# Altium schematic sheet connectors.SchDoc
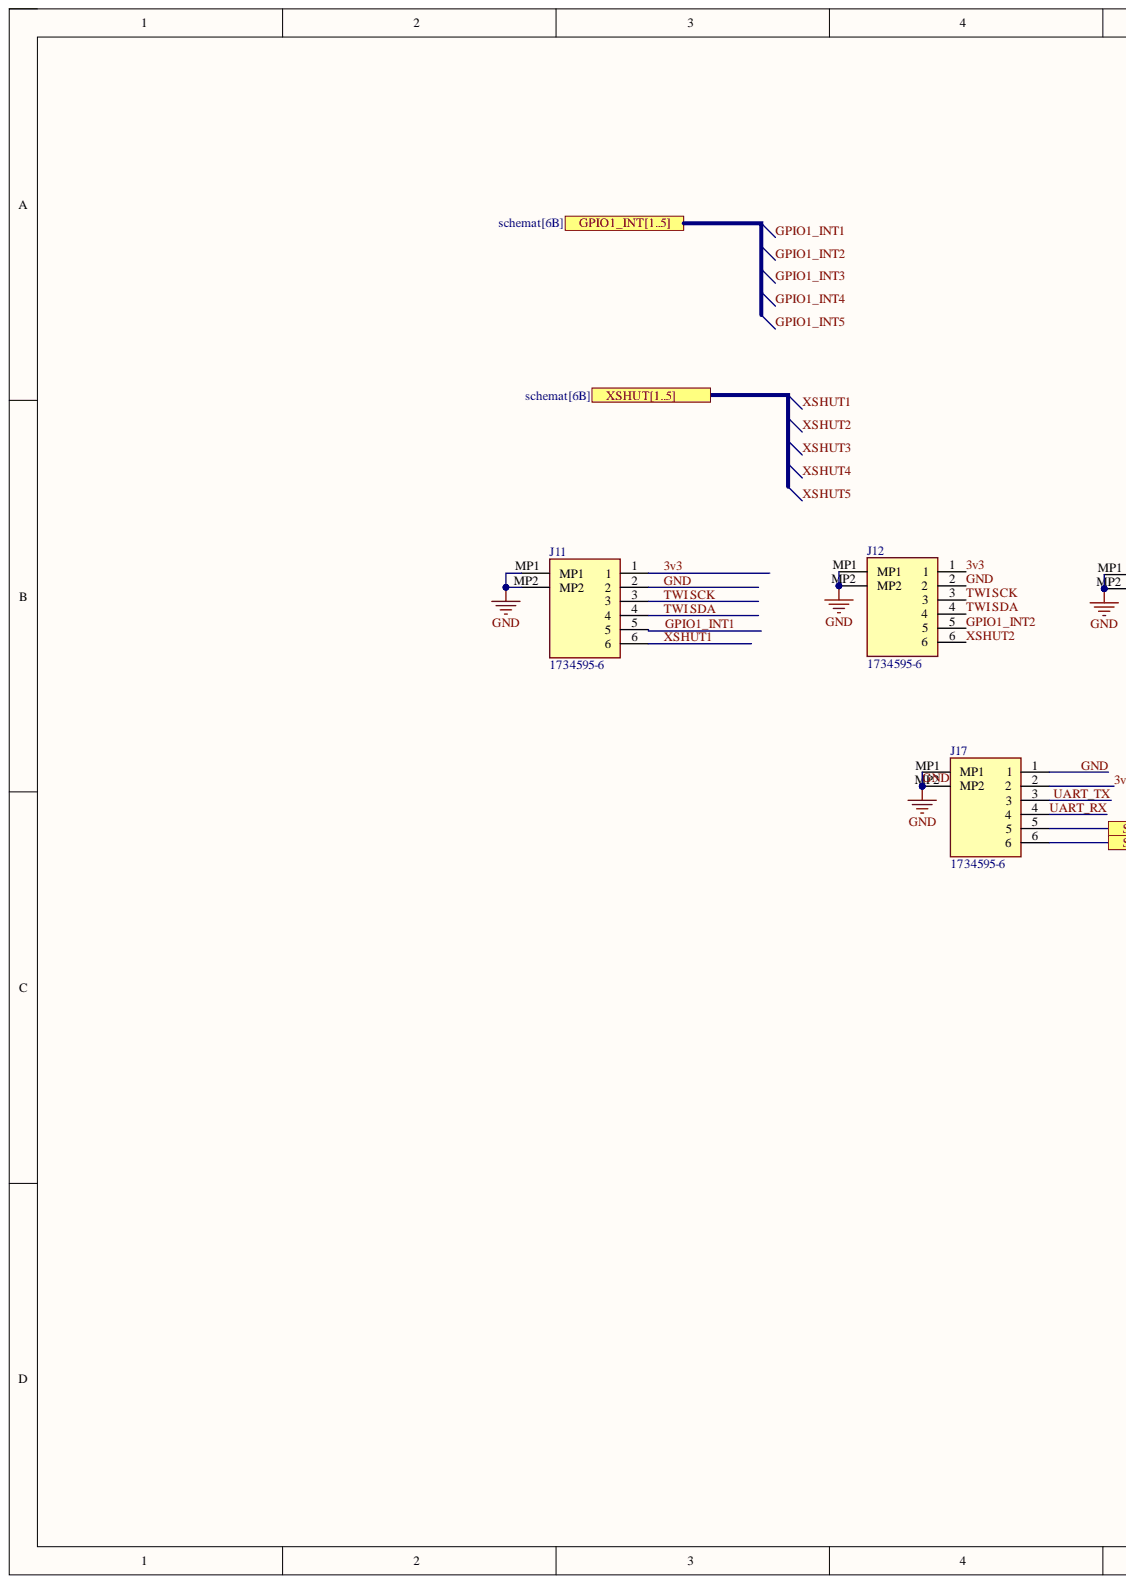
[diagram p0: connectors.SchDoc, left]
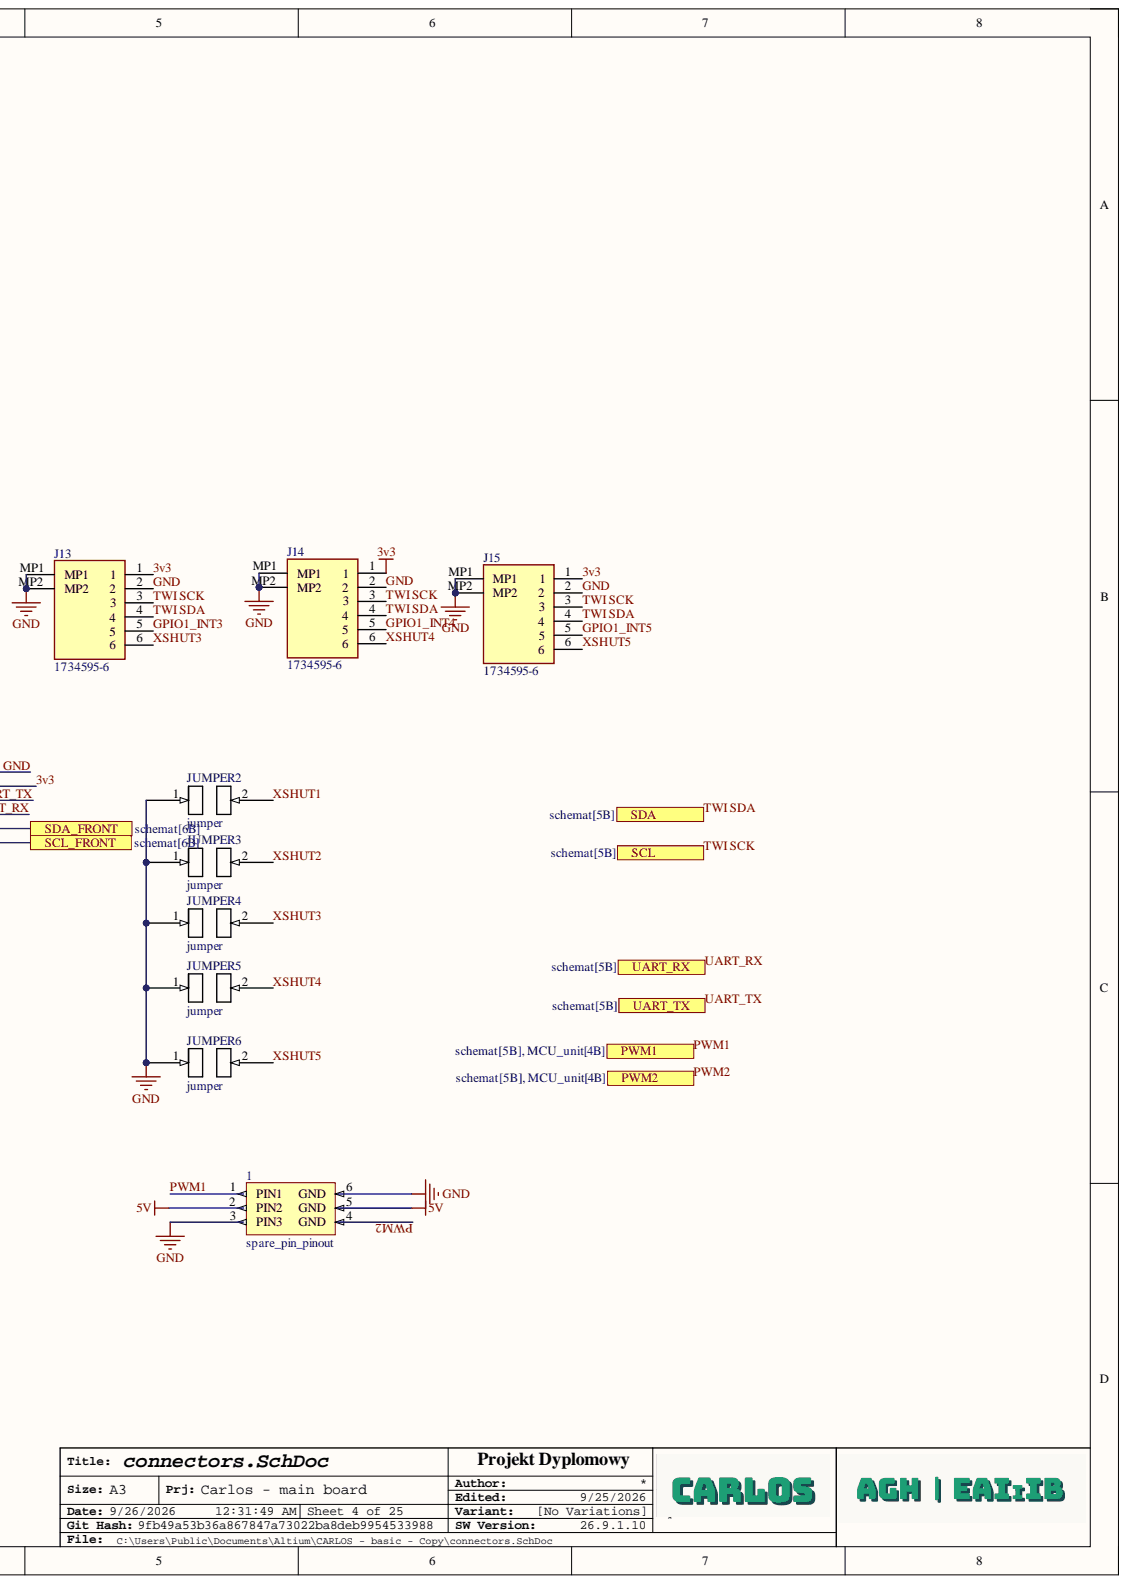
[diagram p1: connectors.SchDoc, right]

## Parts
1  spare_pin_pinout  [spare_pinout]
J11  1734595-6  [17345956]
J12  1734595-6  [17345956]
J13  1734595-6  [17345956]
J14  1734595-6  [17345956]
J15  1734595-6  [17345956]
J17  1734595-6  [17345956]
JUMPER2  jumper  [jumper-Footprint-1]
JUMPER3  jumper  [jumper-Footprint-1]
JUMPER4  jumper  [jumper-Footprint-1]
JUMPER5  jumper  [jumper-Footprint-1]
JUMPER6  jumper  [jumper-Footprint-1]

## Ports
GPIO1_INT1, GPIO1_INT2, GPIO1_INT3, GPIO1_INT4, GPIO1_INT5, GPIO1_INT[1..5], PWM1, PWM2, SCL, SCL_FRONT, SDA, SDA_FRONT, UART_RX, UART_TX, XSHUT1, XSHUT2, XSHUT3, XSHUT4, XSHUT5, XSHUT[1..5]

## Nets
5V: 1.2(PIN2) 1.5(GND)
3v3: J11.1 J12.1 J13.1 J14.1 J15.1 J17.2
GND: 1.3(PIN3) 1.6(GND) J11.2 J11.MP1 J11.MP2 J12.2 J12.MP1 J12.MP2 J13.2 J13.MP1 J13.MP2 J14.2 J14.MP1 J14.MP2 J15.2 J15.MP1 J15.MP2 J17.1 J17.MP1 J17.MP2 JUMPER2.1 JUMPER3.1 JUMPER4.1 JUMPER5.1 JUMPER6.1
GPIO1_INT1: J11.5 ; port GPIO1_INT[1..5]  [also labelled ]
GPIO1_INT2: J12.5 ; port GPIO1_INT[1..5]  [also labelled ]
GPIO1_INT3: J13.5 ; port GPIO1_INT[1..5]  [also labelled ]
GPIO1_INT4: J14.5 ; port GPIO1_INT[1..5]  [also labelled ]
GPIO1_INT5: J15.5 ; port GPIO1_INT[1..5]  [also labelled ]
PWM1: 1.1(PIN1) ; port PWM1
PWM2: 1.4(GND) ; port PWM2
SCL_FRONT: J17.6 ; port SCL_FRONT
SDA_FRONT: J17.5 ; port SDA_FRONT
TWI SCK: J11.3 J12.3 J13.3 J14.3 J15.3 ; port SCL
TWI SDA: J11.4 J12.4 J13.4 J14.4 J15.4 ; port SDA
UART_RX: J17.4 ; port UART_RX
UART_TX: J17.3 ; port UART_TX
XSHUT1: J11.6 JUMPER2.2 ; port XSHUT[1..5]  [also labelled ]
XSHUT2: J12.6 JUMPER3.2 ; port XSHUT[1..5]  [also labelled ]
XSHUT3: J13.6 JUMPER4.2 ; port XSHUT[1..5]  [also labelled ]
XSHUT4: J14.6 JUMPER5.2 ; port XSHUT[1..5]  [also labelled ]
XSHUT5: J15.6 JUMPER6.2 ; port XSHUT[1..5]  [also labelled ]

## Library data of the parts
J11-J15 J17 = 1734595-6: Connector; Manufacturer_Name: TE Connectivity; Arrow Part Number: 1734595-6; Arrow Price/Stock: https://www.arrow.com/en/products/1734595-6/te-connectivity; Component Kind: Standard; Datasheet Link: https://componentsearchengine.com/Datasheets/1/1734595-6.pdf; Height: 4.8mm; Manufacturer_Part_Number: 1734595-6; Mouser Part Number: 571-1734595-6; Mouser Price/Stock: https://www.mouser.co.uk/ProductDetail/TE-Connectivity-Alcoswitch/1734595-6?qs=fvVej%2FMj3jeaZpG40x93Zg%3D%3D
JUMPER2-JUMPER6 = CMP-011-00015-2: Component Kind: Standard; Library Name: Jakub Sikora
1 = spare_pin_pinout: Component Kind: Standard; Library Name: lib_all.IntLib
